# Altium schematic sheet BUCK5V3A.SchDoc
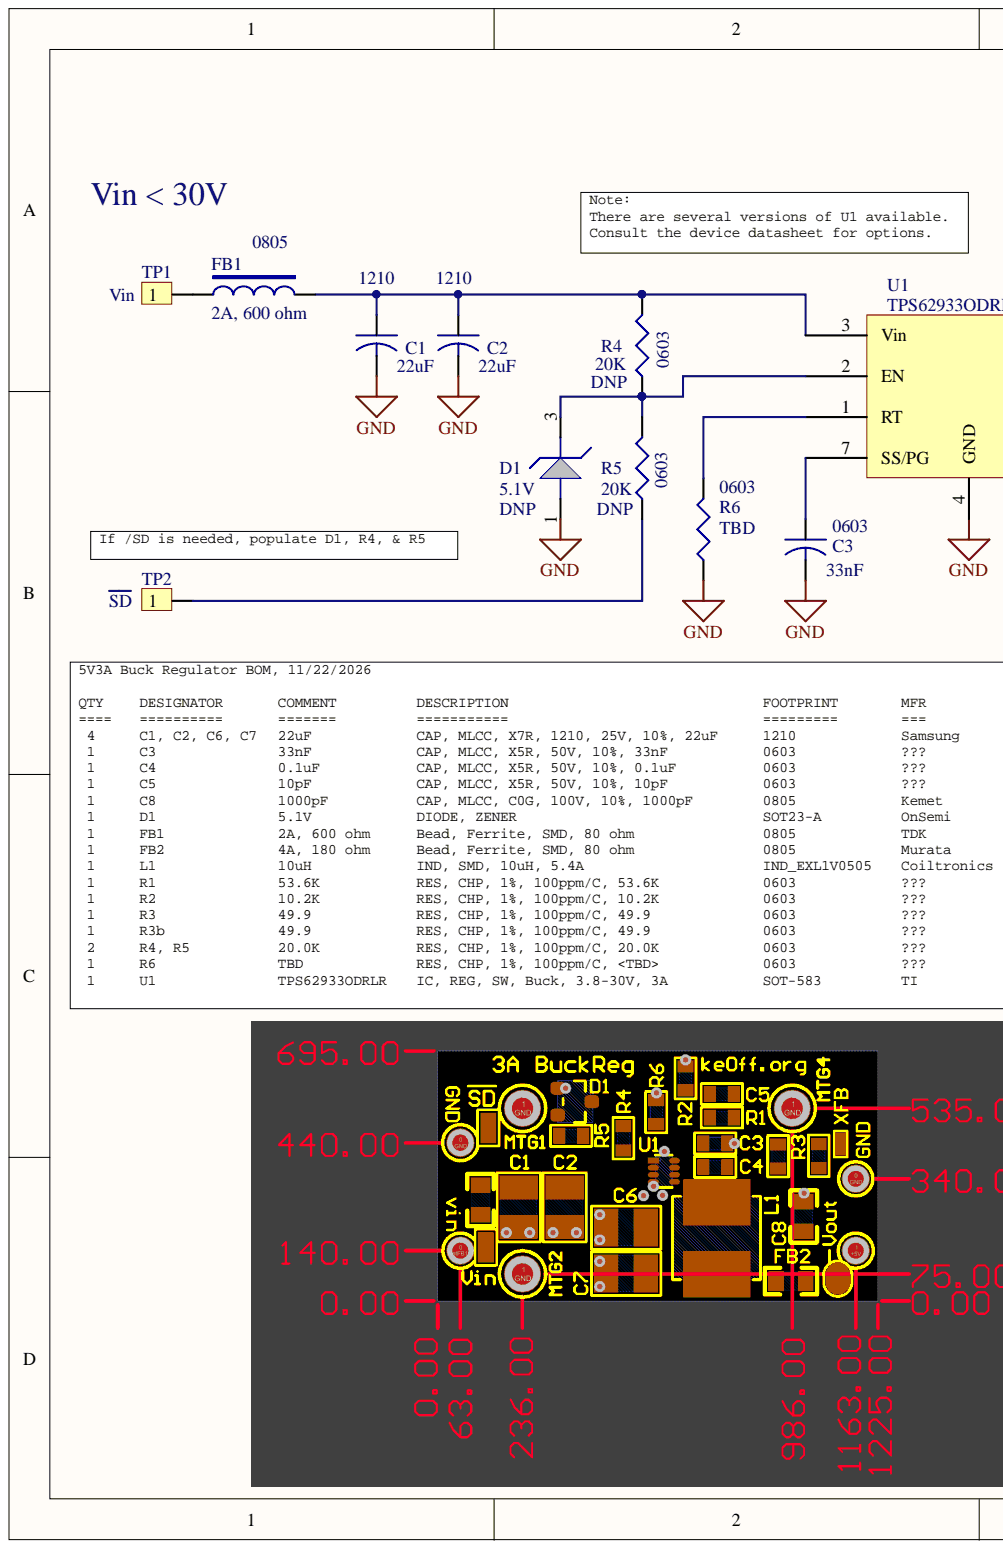
[diagram p0: BUCK5V3A.SchDoc, left]
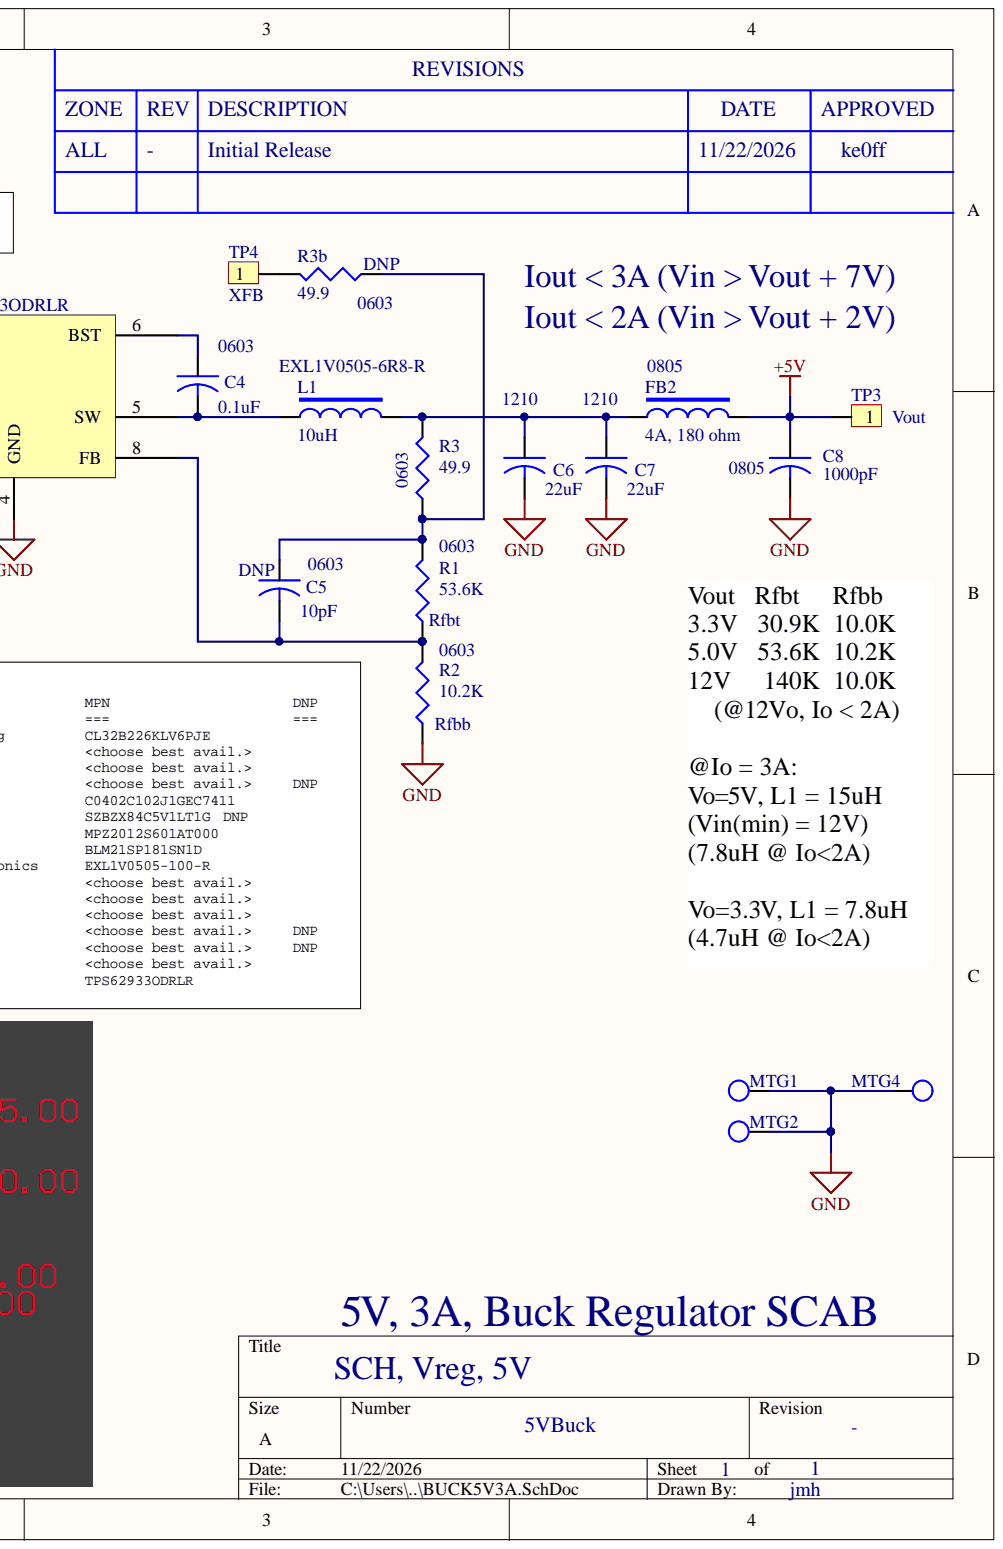
[diagram p1: BUCK5V3A.SchDoc, right]

## Parts
C1  22uF  [1210]
C2  22uF  [1210]
C3  33nF  [0603]
C4  0.1uF  [0603]
C5  10pF  [0603]
C6  22uF  [1210]
C7  22uF  [1210]
C8  1000pF  [0805]
D1  5.1V  [SOT23-A]
FB1  2A, 600 ohm  [0805]
FB2  4A, 180 ohm  [0805]
L1  10uH  MPN-ALT: EXL1V0505-6R8-R  [IND_EXL1V0505]
MTG1  GND  [MTG_HOLE_0.200_0.095]  (value not drawn)  (no BOM)
MTG2  GND  [MTG_HOLE_0.200_0.095]  (no BOM)
MTG4  GND  [MTG_HOLE_0.200_0.095]  (value not drawn)  (no BOM)
R1  53.6K  [0603]
R2  10.2K  [0603]
R3  49.9  [0603]
R3b  49.9  [0603]
R4  value 20K  [0603]  (hidden comment: 20.0K)
R5  value 20K  [0603]  (hidden comment: 20.0K)
R6  TBD  [0603]
TP1  Vin  [TP-SMD-40X80-SQ]  (no BOM)
TP2  S\D\  [TP-SMD-40X80-SQ]  (no BOM)
TP3  Vout  [TP-SMD-65X85-R]  (no BOM)
TP4  XFB  [TP]  (no BOM)
U1  TPS62933ODRLR  [SOT-583]

## Nets
+5V: C8.1 FB2.2 TP3.1
GND: C1.2 C2.2 C3.2 C6.2 C7.2 C8.2 D1.1 MTG1.1 MTG2.1 MTG4.1 R2.1 R6.1 U1.4(GND)
NetC1_1: C1.1 C2.1 FB1.2 R4.2 U1.3(Vin)
NetC3_1: C3.1 U1.7(SS/PG)
NetC4_1: C4.1 U1.6(BST)
NetC4_2: C4.2 L1.1 U1.5(SW)
NetC5_1: C5.1 R1.2 R3.1 R3b.1
NetC5_2: C5.2 R1.1 R2.2 U1.8(FB)
NetC6_1: C6.1 C7.1 FB2.1 L1.2 R3.2
NetD1_3: D1.3 R4.1 R5.2 U1.2(EN)
NetFB1_1: FB1.1 TP1.1
NetR3b_2: R3b.2 TP4.1
NetR5_1: R5.1 TP2.1
NetR6_2: R6.2 U1.1(RT)

## Library data of the parts
C1 C2 C6 = CAP 0805: CAP, MLCC, X7R, 1210, 25V, 10%, 22uF; MFR: Samsung; MPN: CL32B226KLV6PJE; Component Kind: Standard; VOLTAGE: 25V
C3 = CAP 0805: CAP, MLCC, X5R, 50V, 10%, 33nF; MFR: ???; VOLTAGE: 25; Component Kind: Standard; MPN: ???
C4 = CAP 0805: CAP, MLCC, X5R, 50V, 10%, 0.1uF; MFR: ???; VOLTAGE: 25; Component Kind: Standard; MPN: ???
C5 = CAP 0805: CAP, MLCC, X5R, 50V, 10%, 10pF; MFR: ???; VOLTAGE: 25; Component Kind: Standard; DNP: DNP; MPN: ???
C7 = CAP 0805: CAP, MLCC, X7R, 1210, 25V, 10%, 22uF; MFR: Samsung; MFR-ALT: AVX; MPN: CL32B226KLV6PJE; MPN-ALT: TAJB107M010RNJ; Note: 100uF, 10V alt is for low-voltage outputs; Component Kind: Standard; VOLTAGE: 25V
C8 = CAP 0805: CAP, MLCC, C0G, 100V, 10%, 1000pF; MFR: Kemet; MPN: C0402C102J1GEC7411; VOLTAGE: 100; Component Kind: Standard
D1 = DIODE-ZENER-SOT23: DIODE, ZENER; MFR: OnSemi; MPN: SZBZX84C5V1LT1G; ALT-MPN: -; Component Kind: Standard; DNP: DNP; NOTES: -; NOTES2: -; PRI-MPN: -; Library Name: Galaxy SCH-jem-1.08.SchLib
FB1 = FB-100: Bead, Ferrite, SMD, 80 ohm; MFR: TDK; MPN: MPZ2012S601AT000; Component Kind: Standard; Library Name: RFCCA.SchLib
FB2 = FB-100: Bead, Ferrite, SMD, 80 ohm; MFR: Murata; MPN: BLM21SP181SN1D; Component Kind: Standard; Library Name: RFCCA.SchLib
L1 = INDUCTOR2: IND, SMD, 10uH, 5.4A; MFR: Coiltronics; MFR-ALT: Eaton; MPN: EXL1V0505-100-R; Component Kind: Standard; DNP: -; NOTES: -; NOTES2: -; Library Name: Galaxy SCH-jem-1.08.SchLib
MTG1 MTG2 MTG4 = TP_SM: TP, PCB, NOBOM; Library Name: 2102735-1.SchLib
R1 = Res3: RES, CHP, 1%, 100ppm/C, 53.6K; MFR: ???; Component Kind: Standard; LatestRevisionDate: 29-May-2009; LatestRevisionNote: IPC-7351 Footprint Added.; Library Name: Miscellaneous Devices.IntLib; MPN: ???; PackageDescription: Chip Resistor; PackageReference: J1-0402; Published: 8-Jun-2000; Simulation: RESISTOR; Value: 53.6K; Publisher: Altium Limited
R2 = Res3: RES, CHP, 1%, 100ppm/C, 10.2K; MFR: ???; Component Kind: Standard; LatestRevisionDate: 29-May-2009; LatestRevisionNote: IPC-7351 Footprint Added.; Library Name: Miscellaneous Devices.IntLib; MPN: ???; PackageDescription: Chip Resistor; PackageReference: J1-0402; Published: 8-Jun-2000; Simulation: RESISTOR; Value: 10.2K; Publisher: Altium Limited
R3 R3b = Res3: RES, CHP, 1%, 100ppm/C, 49.9; MFR: ???; Component Kind: Standard; LatestRevisionDate: 29-May-2009; LatestRevisionNote: IPC-7351 Footprint Added.; Library Name: Miscellaneous Devices.IntLib; MPN: ???; PackageDescription: Chip Resistor; PackageReference: J1-0402; Published: 8-Jun-2000; Simulation: RESISTOR; Value: 49.9; Publisher: Altium Limited
  R3b: DNP: DNP
R4 R5 = Res3: RES, CHP, 1%, 100ppm/C, 20.0K; MFR: ???; Component Kind: Standard; DNP: DNP; LatestRevisionDate: 29-May-2009; LatestRevisionNote: IPC-7351 Footprint Added.; Library Name: Miscellaneous Devices.IntLib; MPN: ???; PackageDescription: Chip Resistor; PackageReference: J1-0402; Published: 8-Jun-2000; Simulation: RESISTOR; Publisher: Altium Limited
R6 = Res3: RES, CHP, 1%, 100ppm/C, <TBD>; MFR: ???; Component Kind: Standard; LatestRevisionDate: 29-May-2009; LatestRevisionNote: IPC-7351 Footprint Added.; Library Name: Miscellaneous Devices.IntLib; MPN: ???; PackageDescription: Chip Resistor; PackageReference: J1-0402; Published: 8-Jun-2000; Simulation: RESISTOR; Value: TBD; Publisher: Altium Limited
TP1-TP4 = TP: MECH, TEST POINT; ALT-MPN: -; DNP: -; MFR: -; MPN: -; NOTES: -; NOTES2: -; PRI-MPN: -; Library Name: Galaxy SCH-jem-1.08.SchLib
U1 = TPS62933x: IC, REG, SW, Buck, 3.8-30V, 3A; MFR: TI; ALT-MPN: -; Component Kind: Standard; DNP: -; MPN: TPS62933ODRLR; NOTES: -; NOTES2: -; PRI-MPN: -; Library Name: Galaxy SCH-jem-1.08.SchLib
